# Altium schematic sheet rc_cap.SchDoc(rc_cap)
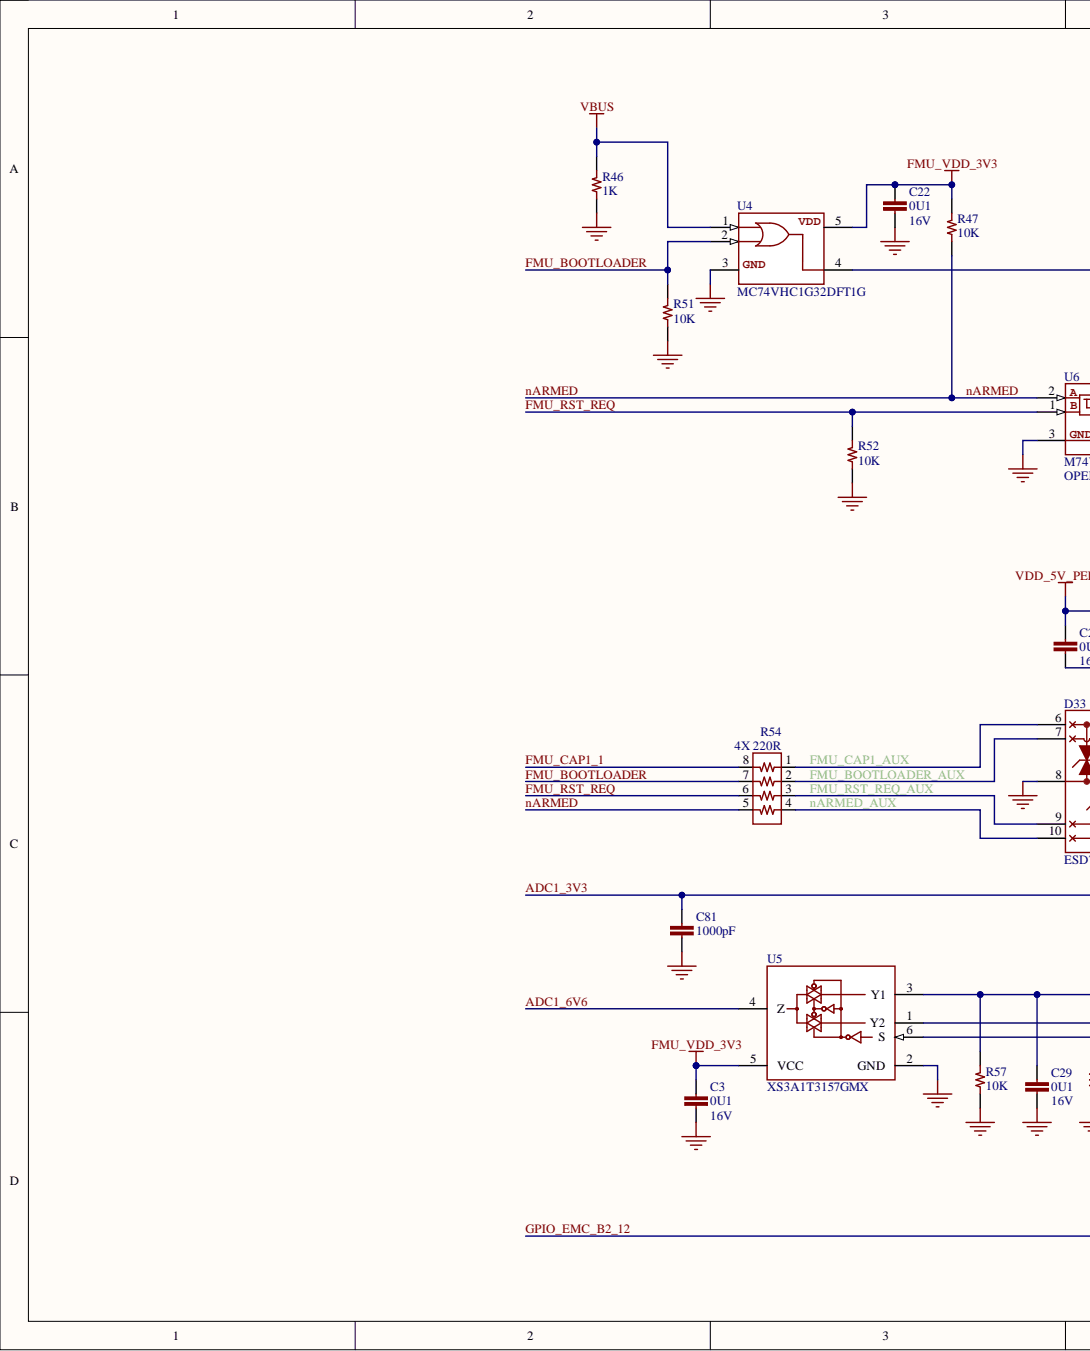
[diagram p0: rc_cap.SchDoc, left]
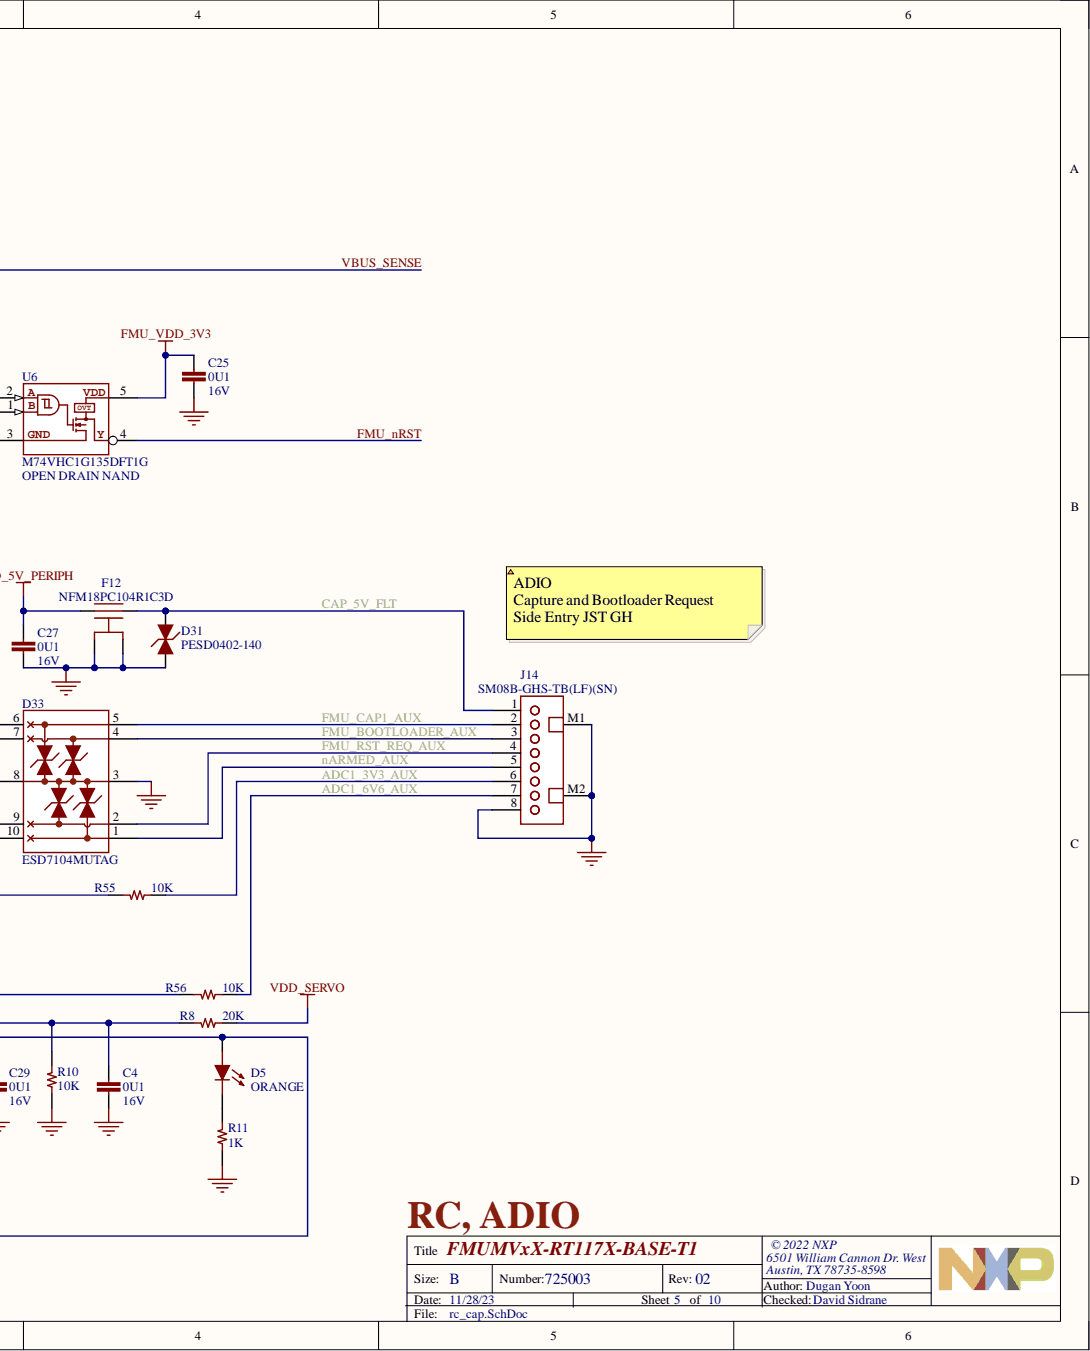
[diagram p1: rc_cap.SchDoc, right]

## Parts
C3  value 0U1  Voltage - Rated: 16V  [CAPC100X50X56L25N]  (hidden comment: CAP CER 0.1UF 10% 16V X7R 0402)
C4  value 0U1  Voltage - Rated: 16V  [CAPC100X50X56L25N]  (hidden comment: CAP CER 0.1UF 10% 16V X7R 0402)
C22  value 0U1  Voltage - Rated: 16V  [CAPC100X50X56L25N]  (hidden comment: CAP CER 0.1UF 10% 16V X7R 0402)
C25  value 0U1  Voltage - Rated: 16V  [CAPC100X50X56L25N]  (hidden comment: CAP CER 0.1UF 10% 16V X7R 0402)
C27  value 0U1  Voltage - Rated: 16V  [CAPC100X50X56L25N]  (hidden comment: CAP CER 0.1UF 10% 16V X7R 0402)
C29  value 0U1  Voltage - Rated: 16V  [CAPC100X50X56L25N]  (hidden comment: CAP CER 0.1UF 10% 16V X7R 0402)
C81  value 1000pF  [CAPC100X50X56L25N]  (hidden comment: CAP CER 1000PF 10% 50V X7R 0402)
D5  Color: ORANGE  [LEDSC80X160X65L40N_ORA]  (hidden comment: LED ORANGE CLEAR 0603 SMD)
D31  Manufacturer Part Number: PESD0402-140  [DIONC100X50X43L20N]  (hidden comment: DIODE TVS BIDIRECTIONAL 14VWM 40VC 0402)
D33  Manufacturer Part Number: ESD7104MUTAG  [UDFN10P50_250X100X55L35X20N]  (hidden comment: DIODE TVS BIDIRECTIONAL 5V 10V 10UDFN)
F12  Manufacturer Part Number: NFM18PC104R1C3D  [NFM18PC104R1C3_0603_NO_VIA]  (hidden comment: FILTER CAP FEEDTHRU 0.1UF 20% 16V 0603)
J14  Manufacturer Part Number: SM08B-GHS-TB(LF)(SN)  [JST_SM08B-GHS-TBLFSN]  (hidden comment: CONN HEADER GH 8POS SIDE 1.25MM SMT)
R8  value 20K  [RESC100X50X40L25]  (hidden comment: RES SMD 20K OHM 1% 1/16W 0402)
R10  value 10K  [RESC100X50X40L25]  (hidden comment: RES SMD 10K OHM 1% 1/16W 0402)
R11  value 1K  [RESC100X50X40L25]  (hidden comment: RES SMD 1K OHM 1% 1/16W 0402)
R46  value 1K  [RESC100X50X40L25]  (hidden comment: RES SMD 1K OHM 1% 1/16W 0402)
R47  value 10K  [RESC100X50X40L25]  (hidden comment: RES SMD 10K OHM 1% 1/16W 0402)
R51  value 10K  [RESC100X50X40L25]  (hidden comment: RES SMD 10K OHM 1% 1/16W 0402)
R52  value 10K  [RESC100X50X40L25]  (hidden comment: RES SMD 10K OHM 1% 1/16W 0402)
R54  value 4X 220R  [RESCAF8P80_320X160X61L30X65N]  (hidden comment: RES ARRAY 4 RES 220 OHM 1206)
R55  value 10K  [RESC100X50X40L25]  (hidden comment: RES SMD 10K OHM 1% 1/16W 0402)
R56  value 10K  [RESC100X50X40L25]  (hidden comment: RES SMD 10K OHM 1% 1/16W 0402)
R57  value 10K  [RESC100X50X40L25]  (hidden comment: RES SMD 10K OHM 1% 1/16W 0402)
U4  Manufacturer Part Number: MC74VHC1G32DFT1G  [SOT23-5P65_210X110L36X22N]  (hidden comment: IC GATE OR 1CH 2-INP SC88A)
U5  Manufacturer Part Number: XS3A1T3157GMX  [PSON6P50_145X100X50L31X21]  (hidden comment: IC SWITCH SPDT X 1 900MOHM 6XSON)
U6  ID: OPEN DRAIN NAND  Manufacturer Part Number: M74VHC1G135DFT1G  [SOT23-5P65_210X110L20X20]  (hidden comment: IC GATE NAND SCHMITT OPEN DRAIN 1CH 2-INP SC88A)

## Nets
FMU_VDD_3V3: C3.1 C22.1 C25.1 R47.1 U4.5(VDD) U5.5(VCC) U6.5
GND: C3.2 C4.2 C22.2 C25.2 C27.2 C29.2 C81.2 D31.1 D33.3 D33.8 F12.2A F12.2B J14.8 J14.M1 J14.M2 R10.2 R11.2 R46.2 R51.2 R52.2 R57.2 U4.3(GND) U5.2(GND) U6.3(GND)
VBUS: R46.1 U4.1
VDD_5V_PERIPH: C27.1 F12.1
VDD_SERVO: R8.2
ADC1_3V3: C81.1 R55.1
ADC1_3V3_AUX: J14.6 R55.2
ADC1_6V6: U5.4(Z)
ADC1_6V6_AUX: J14.7 R56.2
CAP_5V_FLT: D31.2 F12.3 J14.1
FMU_BOOTLOADER: R51.1 R54.7 U4.2
FMU_BOOTLOADER_AUX: D33.4 D33.7 J14.3 R54.2
FMU_CAP1_1: R54.8
FMU_CAP1_AUX: D33.5 D33.6 J14.2 R54.1
FMU_nRST: U6.4(Y)
FMU_RST_REQ: R52.1 R54.6 U6.1
FMU_RST_REQ_AUX: D33.2 D33.9 J14.4 R54.3
GPIO_EMC_B2_12: D5.A U5.6(S)
nARMED: R47.2 R54.5 U6.2
nARMED_AUX: D33.1 D33.10 J14.5 R54.4
VBUS_SENSE: U4.4
NetC4_1: C4.1 R8.1 R10.1 U5.1(Y2)
NetC29_1: C29.1 R56.1 R57.1 U5.3(Y1)
NetD5_C: D5.C R11.1

## Library data of the parts
C3 C4 C22 C25 C27 C29 = CAP_0U1_16V_X7R_0402: Manufacturer: Murata Electronics; Manufacturer Part Number: GCM155R71C104KA55J; Category: Capacitors; Component Kind: Standard; ComponentLink1Description: https://www.digikey.com/product-detail/en/murata-electronics/GCM155R71C104KA55J/490-7998-1-ND/4380283; ComponentLink1URL: https://www.digikey.com/product-detail/en/murata-electronics/GCM155R71C104KA55J/490-7998-1-ND/4380283; Description: CAP CER 0.1UF 10% 16V X7R 0402; Operating Temperature: -55°C ~ 125°C; Packaging: Cut Tape (CT); Part Status: Active; Pricing 1: 1=0.1, 10=0.049, 100=0.022, 500=0.0157, 1000=0.01233, 2500=0.01121, 5000=0.01032 (USD); Stock 1: 6381653; Supplier 1: Digi-Key; Supplier Part Number 1: 490-7998-1-ND; Tolerance: ±10%; Library Name: NscDG_Integrated_Library.SchLib
C81 = CAP_1000PF_10%_50V_X7R_0402: Manufacturer: Murata Electronics North America; Manufacturer Part Number: GCM155R71H102KA37D; Category: Capacitors; Component Kind: Standard; ComponentLink1Description: https://www.digikey.com/product-detail/en/murata-electronics-north-america/GCM155R71H102KA37D/490-4761-1-ND/1641680; ComponentLink1URL: https://www.digikey.com/product-detail/en/murata-electronics-north-america/GCM155R71H102KA37D/490-4761-1-ND/1641680; Description: CAP CER 1000PF 10% 50V X7R 0402; Operating Temperature: -55°C ~ 125°C; Packaging: Cut Tape (CT); Part Status: Active; Pricing 1: 1=0.1, 10=0.02, 100=0.0091, 500=0.0065, 1000=0.00511, 2500=0.00465, 5000=0.00428 (USD); Stock 1: 100000; Supplier 1: Digi-Key; Supplier Part Number 1: 490-4761-1-ND; Tolerance: ±10%; Voltage - Rated: 50V; Library Name: NscDG_Integrated_Library.SchLib
D5 = DIODE_LED_ORANGE_0603: Manufacturer: Lite-On Inc.; Manufacturer Part Number: LTST-C191KFKT; Category: Optoelectronics; Component Kind: Standard; ComponentLink1Description: http://optoelectronics.liteon.com/upload/download/DS22-2000-228/LTST-C191KGKT.PDF; ComponentLink1URL: http://optoelectronics.liteon.com/upload/download/DS22-2000-228/LTST-C191KGKT.PDF; ComponentLink2Description: https://www.digikey.com/product-detail/en/lite-on-inc/LTST-C191KFKT/160-1445-1-ND/386832; ComponentLink2URL: https://www.digikey.com/product-detail/en/lite-on-inc/LTST-C191KFKT/160-1445-1-ND/386832; Description: LED ORANGE CLEAR 0603 SMD; Packaging: Cut Tape (CT); Part Status: Active; Pricing 1: 1=0.34, 10=0.253, 25=0.1872, 100=0.1353 (USD); Stock 1: 146932; Supplier 1: Digi-Key; Supplier Part Number 1: 160-1445-1-ND; Library Name: NscDG_Integrated_Library.SchLib
D31 = DIODE_TVS_0402: Manufacturer: Littelfuse Inc.; Category: Circuit Protection; Component Kind: Standard; ComponentLink1Description: https://www.digikey.com/products/en/circuit-protection/tvs-diodes/144?k=PESD0402-140&k=&pkeyword=PESD0402-140&pv7=2&sf=0&quantity=&ColumnSort=0&page=1&pageSize=25; ComponentLink1URL: https://www.digikey.com/products/en/circuit-protection/tvs-diodes/144?k=PESD0402-140&k=&pkeyword=PESD0402-140&pv7=2&sf=0&quantity=&ColumnSort=0&page=1&pageSize=25; Description: DIODE TVS BIDIRECTIONAL 14VWM 40VC 0402; Operating Temperature: -55°C ~ 125°C (TJ); Packaging: Cut Tape (CT); Part Status: Active; Pricing 1: 10000=0.13154, 30000=0.12096 (USD); Stock 1: 281442; Supplier 1: Digi-Key; Supplier Part Number 1: PESD0402-140CT-ND; Library Name: NscDG_Integrated_Library.SchLib
D33 = DIODE_TVS_4X_10UDFN: Manufacturer: ON Semiconductor; Category: Circuit Protection; Component Kind: Standard; ComponentLink1Description: https://www.digikey.com/product-detail/en/on-semiconductor/ESD7104MUTAG/ESD7104MUTAGOSCT-ND/3487538; ComponentLink1URL: https://www.digikey.com/product-detail/en/on-semiconductor/ESD7104MUTAG/ESD7104MUTAGOSCT-ND/3487538; Description: DIODE TVS BIDIRECTIONAL 5V 10V 10UDFN; Operating Temperature: -55°C ~ 125°C (TJ); Packaging: Cut Tape (CT); Part Status: Active; Pricing 1: 1=0.63, 10=0.541, 100=0.40370, 500=0.31716, 1000=0.24508 (USD); Stock 1: 5750; Supplier 1: Digi-Key; Supplier Part Number 1: ESD7104MUTAGOSCT-ND; Library Name: NscDG_Integrated_Library.SchLib
F12 = FILTER_0.1uF_16V_2A_NO_VIA_0603: Manufacturer: Murata Electronics North America; Category: Filters; Component Kind: Standard; ComponentLink1Description: https://www.digikey.com/products/en/filters/feed-through-capacitors/845?k=NFM18PC104R1C3D&k=&pkeyword=NFM18PC104R1C3D&pv7=2&sf=0&quantity=&ColumnSort=0&page=1&pageSize=25; ComponentLink1URL: https://www.digikey.com/products/en/filters/feed-through-capacitors/845?k=NFM18PC104R1C3D&k=&pkeyword=NFM18PC104R1C3D&pv7=2&sf=0&quantity=&ColumnSort=0&page=1&pageSize=25; Description: FILTER CAP FEEDTHRU 0.1UF 20% 16V 0603; Operating Temperature: -55°C ~ 125°C; Packaging: Cut Tape (CT); Part Status: Active; Pricing 1: 1=0.19, 10=0.173, 25=0.1652, 50=0.1598, 100=0.1544, 250=0.1464, 500=0.12246, 1000=0.09503 (USD); Stock 1: 172860; Supplier 1: Digi-Key; Supplier Part Number 1: 490-6969-1-ND; Tolerance: ±20%; Voltage - Rated: 16V; Library Name: NscDG_Integrated_Library.SchLib
J14 = CONN_JST_GH_8PIN_RA_SMT: Manufacturer: JST Sales America Inc.; System: Connector; Voltage - Rated: 50V; Category: Connectors, Interconnects; Component Kind: Standard; ComponentLink1Description: https://www.digikey.com/products/en/connectors-interconnects/rectangular-connectors-headers-male-pins/314?k=sm08b-GHS&k=&pkeyword=sm08b-GHS&sv=0&pv7=2&sf=0&quantity=&ColumnSort=0&page=1&pageSize=25; ComponentLink1URL: https://www.digikey.com/products/en/connectors-interconnects/rectangular-connectors-headers-male-pins/314?k=sm08b-GHS&k=&pkeyword=sm08b-GHS&sv=0&pv7=2&sf=0&quantity=&ColumnSort=0&page=1&pageSize=25; Description: CONN HEADER GH 8POS SIDE 1.25MM SMT; Operating Temperature: -25°C ~ 85°C; Packaging: Cut Tape (CT); Part Status: Active; Pricing 1: 1=0.59, 10=0.549, 100=0.4208, 500=0.36594, 1000=0.30191 (USD); Stock 1: 90523; Supplier 1: Digi-Key; Supplier Part Number 1: 455-1570-1-ND; Library Name: NscDG_Integrated_Library.SchLib
R8 = RES_20K_1%_1/16W_0402: Manufacturer: Yageo; Manufacturer Part Number: RC0402FR-0720KL; Category: Resistors; Component Kind: Standard; ComponentLink1Description: https://www.digikey.com/products/en?keywords=311-20.0KLRCT-ND; ComponentLink1URL: https://www.digikey.com/products/en?keywords=311-20.0KLRCT-ND; Description: RES SMD 20K OHM 1% 1/16W 0402; Operating Temperature: -55°C ~ 155°C; Packaging: Cut Tape (CT); Part Status: Active; Power: 1/16W; Pricing 1: 1=0.1, 10=0.014, 100=0.0056, 1000=0.00253, 2500=0.0022, 5000=0.00181 (USD); Stock 1: 8493470; Supplier 1: Digi-Key; Supplier Part Number 1: 311-20.0KLRCT-ND; Tolerance: ±1%; Library Name: NscDG_Integrated_Library.SchLib
R10 R47 R51 R52 R55-R57 = RES_10K_1%_1/16W_0402: Manufacturer: Vishay Dale; Manufacturer Part Number: CRCW040210K0FKED; Category: Resistors; Component Kind: Standard; ComponentLink1Description: https://www.digikey.com/products/en?keywords=311-10.0KLRCT-ND; ComponentLink1URL: https://www.digikey.com/products/en?keywords=311-10.0KLRCT-ND; Description: RES SMD 10K OHM 1% 1/16W 0402; Operating Temperature: -55°C ~ 155°C; Packaging: Cut Tape (CT); Part Status: Active; Power: 1/16W; Pricing 1: 1=0.1, 10=0.014, 100=0.0056, 1000=0.00253, 2500=0.0022, 5000=0.00181 (USD); Stock 1: 37996194; Supplier 1: Digi-Key; Supplier Part Number 1: 541-10.0KLCT-ND; Tolerance: ±1%; Library Name: NscDG_Integrated_Library.SchLib
R11 R46 = RES_1K_1%_1/16W_0402: Manufacturer: Yageo; Manufacturer Part Number: RC0402FR-071KL; Category: Resistors; Component Kind: Standard; ComponentLink1Description: https://www.digikey.com/product-detail/en/yageo/RC0402FR-071KL/311-1.00KLRCT-ND/729460; ComponentLink1URL: https://www.digikey.com/product-detail/en/yageo/RC0402FR-071KL/311-1.00KLRCT-ND/729460; Description: RES SMD 1K OHM 1% 1/16W 0402; Operating Temperature: -55°C ~ 155°C; Packaging: Cut Tape (CT); Part Status: Active; Power: 1/16W; Pricing 1: 1=0.1, 10=0.014, 100=0.0056, 1000=0.00253, 2500=0.0022, 5000=0.00181 (USD); Stock 1: 18685853; Supplier 1: Digi-Key; Supplier Part Number 1: 311-1.00KLRCT-ND; Tolerance: ±1%; Library Name: NscDG_Integrated_Library.SchLib
R54 = RES_ARRAY_220_5%_1/16W_1206: Manufacturer: Yageo; Manufacturer Part Number: YC164-JR-07220RL; Power: 1/16W; Tolerance: ±5%; Category: Resistors; Component Kind: Standard; ComponentLink1Description: https://www.digikey.com/products/en/resistors/resistor-networks-arrays/50?k=&pkeyword=&pv16=9574&sf=0&FV=fffc000d%2C1c0002%2C1f140000%2C24280001%2Cffe00032%2C40136&quantity=&ColumnSort=0&page=1&pageSize=25; ComponentLink1URL: https://www.digikey.com/products/en/resistors/resistor-networks-arrays/50?k=&pkeyword=&pv16=9574&sf=0&FV=fffc000d%2C1c0002%2C1f140000%2C24280001%2Cffe00032%2C40136&quantity=&ColumnSort=0&page=1&pageSize=25; Description: RES ARRAY 4 RES 220 OHM 1206; Operating Temperature: -55°C ~ 155°C; Packaging: Cut Tape (CT); Part Status: Active; Pricing 1: 1=0.1, 10=0.014, 100=0.0056, 1000=0.00253, 2500=0.0022, 5000=0.00181 (USD); Stock 1: 17927; Supplier 1: Digi-Key; Supplier Part Number 1: YC164J-220CT-ND; Library Name: NscDG_Integrated_Library.SchLib
U4 = IC_GATE_SINGLE_OR_SC88A: Manufacturer: ON Semiconductor; Category: Integrated Circuits (ICs); Component Kind: Standard; ComponentLink1Description: https://www.digikey.com/product-detail/en/on-semiconductor/MC74VHC1G32DFT1G/MC74VHC1G32DFT1GOSCT-ND/1967119; ComponentLink1URL: https://www.digikey.com/product-detail/en/on-semiconductor/MC74VHC1G32DFT1G/MC74VHC1G32DFT1GOSCT-ND/1967119; Description: IC GATE OR 1CH 2-INP SC88A; Operating Temperature: -55°C ~ 125°C; Packaging: Cut Tape (CT); Part Status: Active; Pricing 1: 1=0.31 (USD); Stock 1: 5437; Supplier 1: Digi-Key; Supplier Part Number 1: MC74VHC1G32DFT1GOSCT-ND; Library Name: NscDG_Integrated_Library.SchLib
U5 = IC_XS3A1T3157GMX: Manufacturer: Nexperia USA Inc.; Category: Integrated Circuits (ICs); Component Kind: Standard; ComponentLink1Description: https://www.digikey.com/en/products/detail/nexperia-usa-inc/XS3A1T3157GMX/12325034; ComponentLink1URL: https://www.digikey.com/en/products/detail/nexperia-usa-inc/XS3A1T3157GMX/12325034; Description: IC SWITCH SPDT X 1 900MOHM 6XSON; Max Operating Temperature: 125°C; Min Operating Temperature: -40°C; Packaging: Cut Tape (CT); Pricing 1: 1=0.43 (USD); Stock 1: 58385; Supplier 1: Digi-Key; Supplier Part Number 1: 1727-XS3A1T3157GMXCT-ND; Library Name: NscDG_Integrated_Library.SchLib
U6 = IC_GATE_SINGLE_NAND_SCHMITT_OPEN_DRAIN: Manufacturer: ON Semiconductor; Category: Integrated Circuits (ICs); Component Kind: Standard; ComponentLink1Description: https://www.digikey.com/product-detail/en/on-semiconductor/M74VHC1G135DFT1G/M74VHC1G135DFT1GOSDKR-ND/4331868; ComponentLink1URL: https://www.digikey.com/product-detail/en/on-semiconductor/M74VHC1G135DFT1G/M74VHC1G135DFT1GOSDKR-ND/4331868; Description: IC GATE NAND SCHMITT OPEN DRAIN 1CH 2-INP SC88A; Operating Temperature: -55°C ~ 125°C; Packaging: Cut Tape (CT); Part Status: Active; Pricing 1: 1=0.34, 10=0.28, 100=0.1485, 500=0.09772, 1000=0.06645 (USD); Stock 1: 57000; Supplier 1: Digi-Key; Supplier Part Number 1: M74VHC1G135DFT1GOSDKR-ND; Library Name: NscDG_Integrated_Library.SchLib
